# Altium schematic sheet MCU.SchDoc
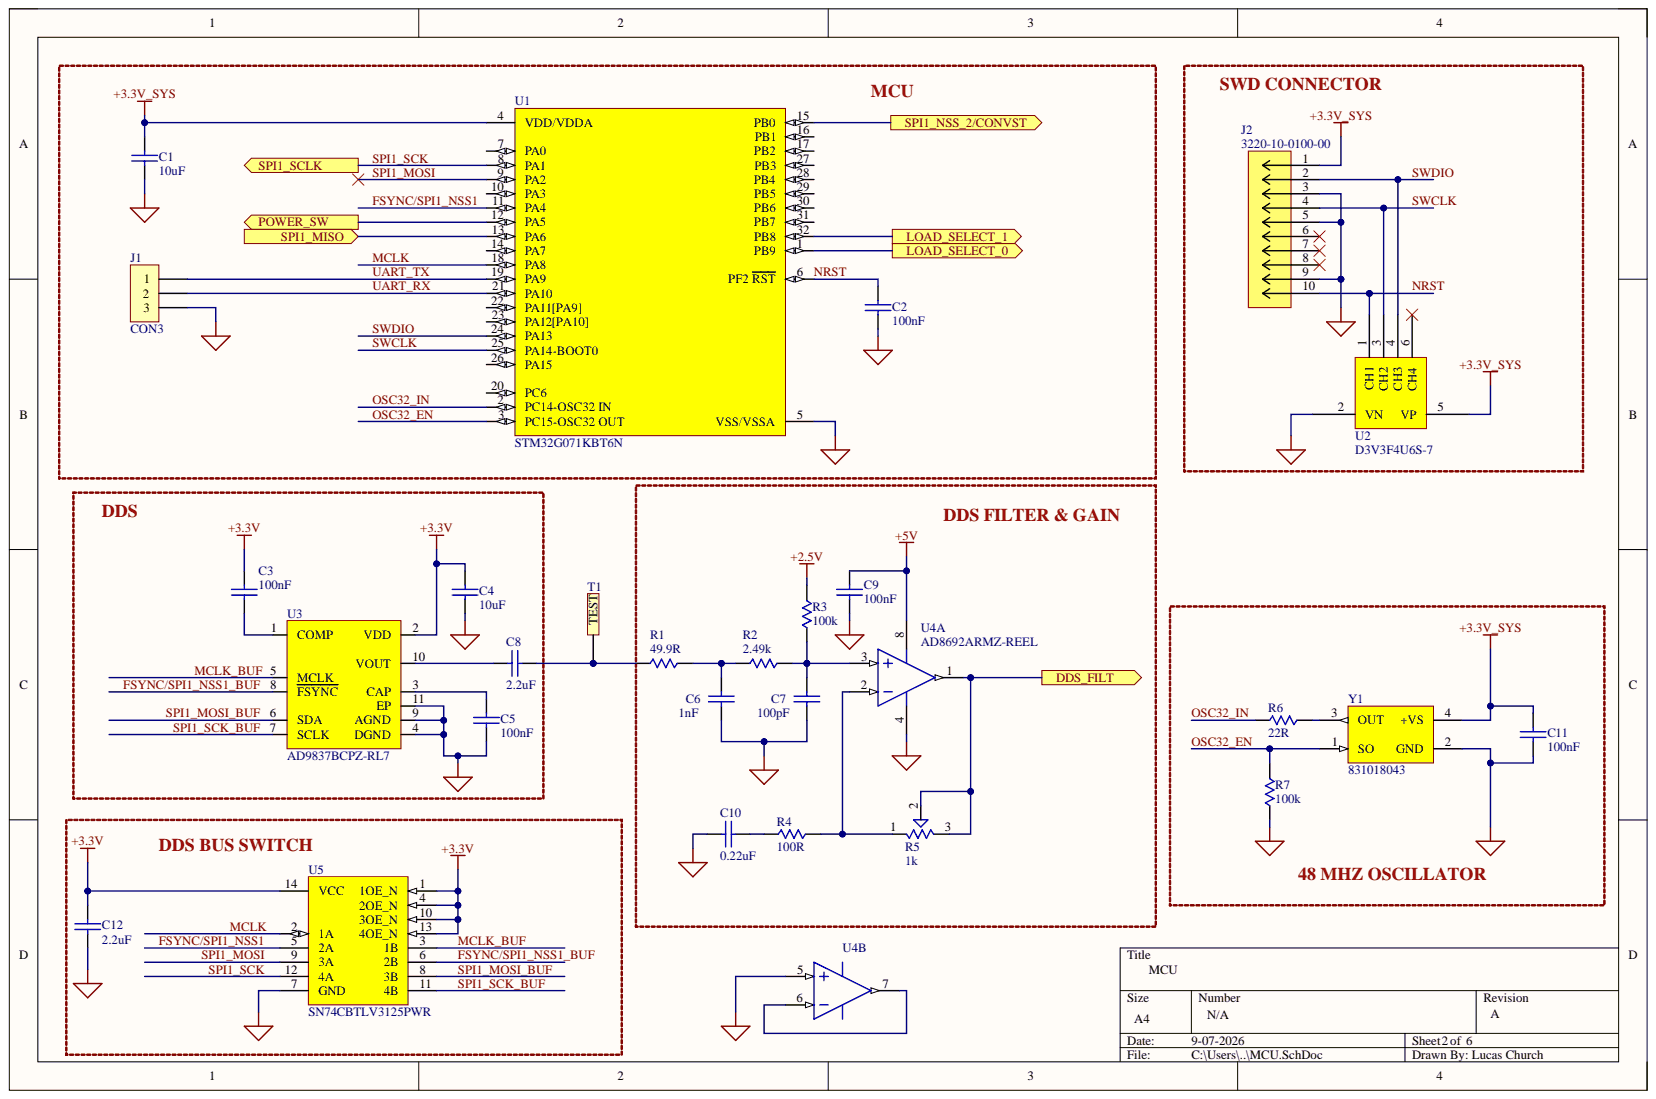
[diagram p0: MCU.SchDoc]

## Parts
C1  10uF  [0603-CAP]
C2  100nF  [0603-CAP]
C3  100nF  [0603-CAP]
C4  10uF  [0603-CAP]
C5  100nF  [0603-CAP]
C6  1nF  [0603-CAP]
C7  100pF  [0603-CAP]
C8  2.2uF  [0603-CAP]
C9  100nF  [0603-CAP]
C10  0.22uF  [0603-CAP]
C11  100nF  [0603-CAP]
C12  2.2uF  [0603-CAP]
J1  CON3  [SIP3]
J2  3220-10-0100-00  [CONN_3220-10-0100-00_CNC]
R1  49.9R  [0603-RES]
R2  2.49k  [0603-RES]
R3  100k  [0603-RES]
R4  100R  [0603-RES]
R5  1k  [TRIM_PVG5A102C03R00]
R6  22R  [0603-RES]
R7  100k  [0603-RES]
T1  5002K  [TESTPOINT White]  (value not drawn)
U1  STM32G071KBT6N  [FP-LQFP32-5V-IPC_C]
U2  D3V3F4U6S-7  [SOT-363_DIO]
U3  AD9837BCPZ-RL7  [LFCSP-WD (3x3) - 10 Pin EPAD]
U4  AD8692ARMZ-REEL  [ADI-RM-8_M]  (parts A,B)
U5  SN74CBTLV3125PWR  [PW0014A-IPC_A]
Y1  831018043  [WE-SPXO_CFPS-73]

## Ports
DDS_FILT, LOAD_SELECT_0, LOAD_SELECT_1, POWER_SW, SPI1_MISO, SPI1_NSS_2/CONVST, SPI1_SCLK

## Nets
+2.5V: R3.2
+3.3V: C3.1 C4.1 C12.1 U3.2(VDD) U5.1(1OE_N) U5.4(2OE_N) U5.10(3OE_N) U5.13(4OE_N) U5.14(VCC)
+3.3V_SYS: C1.1 C11.2 J2.1 U1.4(VDD/VDDA) U2.5(VP) Y1.4(+VS)
+5V: C9.1 U4.8
GND: C1.2 C2.1 C4.2 C5.2 C6.2 C7.2 C9.2 C10.1 C11.1 C12.2 J1.3 J2.3 J2.5 J2.9 R7.1 U1.5(VSS/VSSA) U2.2(VN) U3.4(DGND) U3.9(AGND) U3.11(EP) U4.4 U4.5 U5.7(GND) Y1.2(GND)
DDS_FILT: R5.2 R5.3 U4.1 ; port DDS_FILT
FSYNC/SPI1_NSS1: U1.11(PA4) U5.5(2A)
FSYNC/SPI1_NSS1_BUF: U3.8(FSYNC) U5.6(2B)
LOAD_SELECT_0: U1.1(PB9) ; port LOAD_SELECT_0
LOAD_SELECT_1: U1.32(PB8) ; port LOAD_SELECT_1
MCLK: U1.18(PA8) U5.2(1A)
MCLK_BUF: U3.5(MCLK) U5.3(1B)
NRST: C2.2 J2.10 U1.6(PF2_RST) U2.1(CH1)
OSC32_EN: R7.2 U1.3(PC15-OSC32_OUT) Y1.1(SO)
OSC32_IN: R6.1 U1.2(PC14-OSC32_IN)
POWER_SW: U1.12(PA5) ; port POWER_SW
SPI1_MISO: U1.13(PA6) ; port SPI1_MISO
SPI1_MOSI: U1.9(PA2) U5.9(3A)
SPI1_MOSI_BUF: U3.6(SDA) U5.8(3B)
SPI1_NSS_2/CONVST: U1.15(PB0) ; port SPI1_NSS_2/CONVST
SPI1_SCK: U1.8(PA1) U5.12(4A) ; port SPI1_SCLK
SPI1_SCK_BUF: U3.7(SCLK) U5.11(4B)
SWCLK: J2.4 U1.25(PA14-BOOT0) U2.3(CH2)
SWDIO: J2.2 U1.24(PA13) U2.4(CH3)
UART_RX: J1.2 U1.21(PA10)
UART_TX: J1.1 U1.19(PA9)
NetC3_2: C3.2 U3.1(COMP)
NetC5_1: C5.1 U3.3(CAP)
NetC6_1: C6.1 R1.2 R2.1
NetC7_1: C7.1 R2.2 R3.1 U4.3
NetC8_1: C8.1 U3.10(VOUT)
NetC8_2: C8.2 R1.1 T1.1
NetC10_2: C10.2 R4.1
NetJ2_6: J2.6
NetJ2_7: J2.7
NetJ2_8: J2.8
NetR4_2: R4.2 R5.1 U4.2
NetR6_2: R6.2 Y1.3(OUT)
NetU1_7: U1.7(PA0)
NetU1_10: U1.10(PA3)
NetU1_14: U1.14(PA7)
NetU1_16: U1.16(PB1)
NetU1_17: U1.17(PB2)
NetU1_20: U1.20(PC6)
NetU1_22: U1.22(PA11[PA9])
NetU1_23: U1.23(PA12[PA10])
NetU1_26: U1.26(PA15)
NetU1_27: U1.27(PB3)
NetU1_28: U1.28(PB4)
NetU1_29: U1.29(PB5)
NetU1_30: U1.30(PB6)
NetU1_31: U1.31(PB7)
NetU2_6: U2.6(CH4)
NetU4_6: U4.6 U4.7

## Library data of the parts
C1 C4 = CGA3E2C0G1H472J080AA: CAP CER 10uF 6.3V X5R 0603; Manufacturer 1: Murata Electronics; Manufacturer Part Number 1: GRM188Z71A106KA73D; Database Table Name: Ceramic 0603$; Detailed Description: CAP CER 100 NF 50V X7R 0603; Tech#: SMT; Component Kind: Standard; Price(100): 0.1434; Price(250): 0.10756; Supplier 1: DigiKey; Supplier 2: Mouser; Supplier Part Number 1: 490-GRM188Z71A106KA73DCT-ND; Supplier Part Number 2: 810-CGA3E2C0G1H472J; Library Name: SGL_Capacitors.DBLib
C2 C3 C5 C9 C11 = CGA3E2C0G1H472J080AA: CAP CER 100 NF 50V X7R 0603; Manufacturer 1: YAGEO; Manufacturer Part Number 1: CC0603KRX7R9BB104; Database Table Name: Ceramic 0603$; Tech#: SMT; Component Kind: Standard; Detailed Description: CAP CER 100 NF 50V X7R 0603; Price(100): 0.1434; Price(250): 0.10756; Supplier 1: DigiKey; Supplier 2: Mouser; Supplier Part Number 1: 311-1344-1-ND; Supplier Part Number 2: 810-CGA3E2C0G1H472J; Library Name: SGL_Capacitors.DBLib
C6 = CGA3E2C0G1H472J080AA: CAP CER 1000 PF 50V C0G 0603; Manufacturer 1: Murata; Manufacturer Part Number 1: GRM1885C1H102JA01D; Database Table Name: Ceramic 0603$; Detailed Description: CAP CER 100 NF 50V X7R 0603; Tech#: SMT; Component Kind: Standard; Price(100): 0.1434; Price(250): 0.10756; Supplier 1: DigiKey; Supplier 2: Mouser; Supplier Part Number 1: 490-1451-1-ND; Supplier Part Number 2: 810-CGA3E2C0G1H472J; Library Name: SGL_Capacitors.DBLib
C7 = CGA3E2C0G1H472J080AA: CAP CER 100 PF 50V C0G 0603; Manufacturer 1: YAGEO; Manufacturer Part Number 1: CC0603JRNPO9BN101; Database Table Name: Ceramic 0603$; Tech#: SMT; Component Kind: Standard; Detailed Description: CAP CER 100 PF 50V C0G 0603; Price(100): 0.1434; Price(250): 0.10756; Supplier 1: DigiKey; Supplier 2: Mouser; Supplier Part Number 1: 311-1069-1-ND; Supplier Part Number 2: 810-CGA3E2C0G1H472J; Library Name: SGL_Capacitors.DBLib
C8 C12 = CGA3E2C0G1H472J080AA: CAP CER 2.2UF 35V X5R 0603; Manufacturer 1: Murata Electronics; Manufacturer Part Number 1: GRM188R6YA225MA12D; Database Table Name: Ceramic 0603$; Tech#: SMT; Component Kind: Standard; Detailed Description: CAP CER 2.2UF 35V X5R 0603; Price(100): 0.1434; Price(250): 0.10756; Supplier 1: DigiKey; Supplier 2: Mouser; Supplier Part Number 1: 490-10486-1-ND; Supplier Part Number 2: 810-CGA3E2C0G1H472J; Library Name: SGL_Capacitors.DBLib
C10 = CGA3E2C0G1H472J080AA: CAP CER 0.22UF 25V X7R 0603; Manufacturer 1: Murata Electronics; Manufacturer Part Number 1: GRM188R71E224KA88D; Database Table Name: Ceramic 0603$; Tech#: SMT; Component Kind: Standard; Detailed Description: CAP CER 0.22UF 25V X7R 0603; Price(100): 0.1434; Price(250): 0.10756; Supplier 1: DigiKey; Supplier 2: Mouser; Supplier Part Number 1: 490-3290-1-ND; Supplier Part Number 2: 810-CGA3E2C0G1H472J; Library Name: SGL_Capacitors.DBLib
J1 = CON3: Connector; Component Kind: Standard; Library Name: BioZ.SchLib
J2 = 3220-10-0100-00: Description; Manufacturer_Name: CNC Tech; Component Kind: Standard; Manufacturer_Part_Number: 3220-10-0100-00; RefDes: RefDes; Type: Type; Copyright: Copyright (C) 2025 Ultra Librarian. All rights reserved.; Library Name: BioZ.SchLib
R1 = 4.99K 0603: RES SMD 49.9OHM 0.1% 1/10W 0603; Manufacturer 1: Yageo; Manufacturer Part Number 1: RT0603BRD0749R9L; Database Table Name: 0603$; Tech#: SMT; Component Kind: Standard; Detailed Description: RES SMD 49.9OHM 0.1% 1/10W 0603; Price(100): 0.023; Simulation: Resistor; Supplier 1: DigiKey; Supplier 2: Mouser; Supplier Part Number 1: YAG1672CT-ND; Supplier Part Number 2: 754-RR0816P-4991D68H; Library Name: SGL_Resistives.DBLib
R2 = 4.99K 0603: RES SMD 2.49kOHM 0.1% 1/10W 0603; Manufacturer 1: Panasonic; Manufacturer Part Number 1: ERA-3AEB2491V; Database Table Name: 0603$; Tech#: SMT; Component Kind: Standard; Detailed Description: RES SMD 2.49kOHM 0.1% 1/10W 0603; Price(100): 0.023; Simulation: Resistor; Supplier 1: DigiKey; Supplier 2: Mouser; Supplier Part Number 1: RES SMD 2.49KOHM 0.1% 1/10W 0603; Supplier Part Number 2: 754-RR0816P-4991D68H; Library Name: SGL_Resistives.DBLib
R3 R7 = 4.99K 0603: RES SMD 100KOHM 0.1% 1/10W 0603; Manufacturer 1: YAGEO; Manufacturer Part Number 1: RT0603BRD07100KL; Database Table Name: 0603$; Detailed Description: RES SMD 4.99KOHM 0.5% 1/16W 0603; Tech#: SMT; Component Kind: Standard; Price(100): 0.023; Simulation: Resistor; Supplier 1: DigiKey; Supplier 2: Mouser; Supplier Part Number 1: YAG1235CT-ND; Supplier Part Number 2: 754-RR0816P-4991D68H; Library Name: SGL_Resistives.DBLib
R4 = 4.99K 0603: RES SMD 100OHM 0.1% 1/10W 0603; Manufacturer 1: Susumu; Manufacturer Part Number 1: RG1608P-101-B-T5; Database Table Name: 0603$; Detailed Description: RES SMD 4.99KOHM 0.5% 1/16W 0603; Tech#: SMT; Component Kind: Standard; Price(100): 0.023; Simulation: Resistor; Supplier 1: DigiKey; Supplier 2: Mouser; Supplier Part Number 1: RR08P4.99KDCT-ND; Supplier Part Number 2: 754-RR0816P-4991D68H; Library Name: SGL_Resistives.DBLib
R5 = PVG5A102C03R00: TRIMMER-SMD 4MM SQ MT CERMET Square / 12 turn / Sealed | Bourns PVG5A102C03R00; Check_prices: https://www.snapeda.com/parts/PVG5A102C03R00/Bourns/view-part/?ref=eda; Component Kind: Standard; MF: Bourns; MP: PVG5A102C03R00; Package: SMD-3 Bourns; SnapEDA_Link: https://www.snapeda.com/parts/PVG5A102C03R00/Bourns/view-part/?ref=snap; Library Name: BioZ.SchLib
R6 = 4.99K 0603: RES SMD 22OHM 0.1% 1/10W 0603; Manufacturer 1: YAGEO; Manufacturer Part Number 1: RT0603BRD0722RL; Database Table Name: 0603$; Tech#: SMT; Component Kind: Standard; Detailed Description: RES SMD 22OHM 0.1% 1/10W 0603; Price(100): 0.023; Simulation: Resistor; Supplier 1: DigiKey; Supplier 2: Mouser; Supplier Part Number 1: 13-RT0603BRD0722RLCT-ND; Supplier Part Number 2: 754-RR0816P-4991D68H; Library Name: SGL_Resistives.DBLib
T1 = 5002K: PC TEST POINT MINIATURE WHITE; Manufacturer 1: Keystone Electronics; Database Table Name: Testpoints$; Detailed Description: Connector, TestPoint White; Location: F1-33; Tech#: T/H; Component Kind: Standard; Manufacturer Part Number 1: 5002K; Price(1): 0.56; Price(10): 0.506; Price(100): 0.3541; Supplier 1: DigiKey; Supplier 2: Mouser; Supplier Part Number 1: 36-5002-ND; Supplier Part Number 2: 534-5002; Library Name: SGL_Connectors.DBLib
U1 = CMP-12105-000115-1: IC MCU 32BIT 128KB FLASH 32LQFP; Core Architecture: ARM; Frequency: 64MHz; Interface: I2C; Number of I/Os: 30; Oscillator Type: Internal; Peripherals: Brown-out Detect/Reset; Case/Package: LQFP; Component Kind: Standard; Max Operating Temperature: 85°C; Memory Size: 128KB; Memory Type: FLASH; Min Operating Temperature: -40°C; Number of Pins: 32; Packaging: Cut Tape; RoHS Compliant: Yes; Library Name: BioZ.SchLib
U2 = D3V3F4U6S-7: Description; Manufacturer_Name: Diodes Inc; Component Kind: Standard; Manufacturer_Part_Number: D3V3F4U6S-7; RefDes: RefDes; Type: Type; Copyright: Copyright (C) 2025 Ultra Librarian. All rights reserved.; Library Name: BioZ.SchLib
U3 = AD9837BCPZ-RL7: Direct Digital Synthesis IC 10 b 16 MHz 28 b Tuning 10-LFCSP-WD (3x3); Component Kind: Standard; Library Name: BioZ.SchLib
U4 = CMP-0384-01747-1: Double Channel Wide Bandwidth Operational Amplifier, 10 MHz BW, 5 V/us SR, Industrial, 8-pin SOP (RM-8), Reel; Generic Part Number: AD8692; Manufacturer: Analog Devices; Amplifiers Per Package: 2; Automotive Qualified: Yes; Input Bias Current: 200fA; Input Offset Voltage: 400uV; Iq/Amp: 1.05mA; Slew Rate: 5V/us; Small Signal Bandwidth: 10MHz; V Span Max: 6V; V Span Min: 2.7V; Code_JEDEC: MO-187-AA; Component Kind: Standard; ComponentLink1Description: Manufacturer URL; ComponentLink1URL: http://www.analog.com/en/index.html; ComponentLink2Description: Datasheet; ComponentLink2URL: http://www.analog.com/static/imported-files/data_sheets/AD8691_8692_8694.pdf; ComponentLink3Description: Package Specification; ComponentLink3URL: http://www.analog.com/static/imported-files/packages/PKG_PDF/MSOP%28RM%29/RM_8.pdf; DatasheetVersion: Rev. E; Library Name: Altium Content Vault; Mounting Technology: Surface Mount; PackageDescription: 8-Pin Mini Small Outline Package, Body 3 x 3 mm, Pitch 0.65 mm; PackageReference: RM-8; PartNumber: AD8692ARMZ-REEL
U5 = SN74CBTLV3125PWR: 3.3-V, 1:1 (SPST), 4-channel FET bus switch with powered-off protection 14-TSSOP -40 to 85; Manufacturer_Name: Texas Instruments; Component Kind: Standard; Datasheet: https://www.ti.com/lit/gpn/sn74cbtlv3125; Manufacturer_Part_Number: SN74CBTLV3125PWR; RefDes: RefDes; TYPE: TYPE; Copyright: Copyright (C) 2026 Ultra Librarian. All rights reserved.; Library Name: BioZ.SchLib
Y1 = WE-SPXO_CFPS-73: MF: {'manufacturer': u'W\xfcrth Elektronik'}; Availability: Unavailable; Component Kind: Standard; MP: 831018043; Package: None; Price: None; Library Name: BioZ.SchLib
